# Altium schematic sheet STM_Bluetooth.SchDoc(STM_Bluetooth)
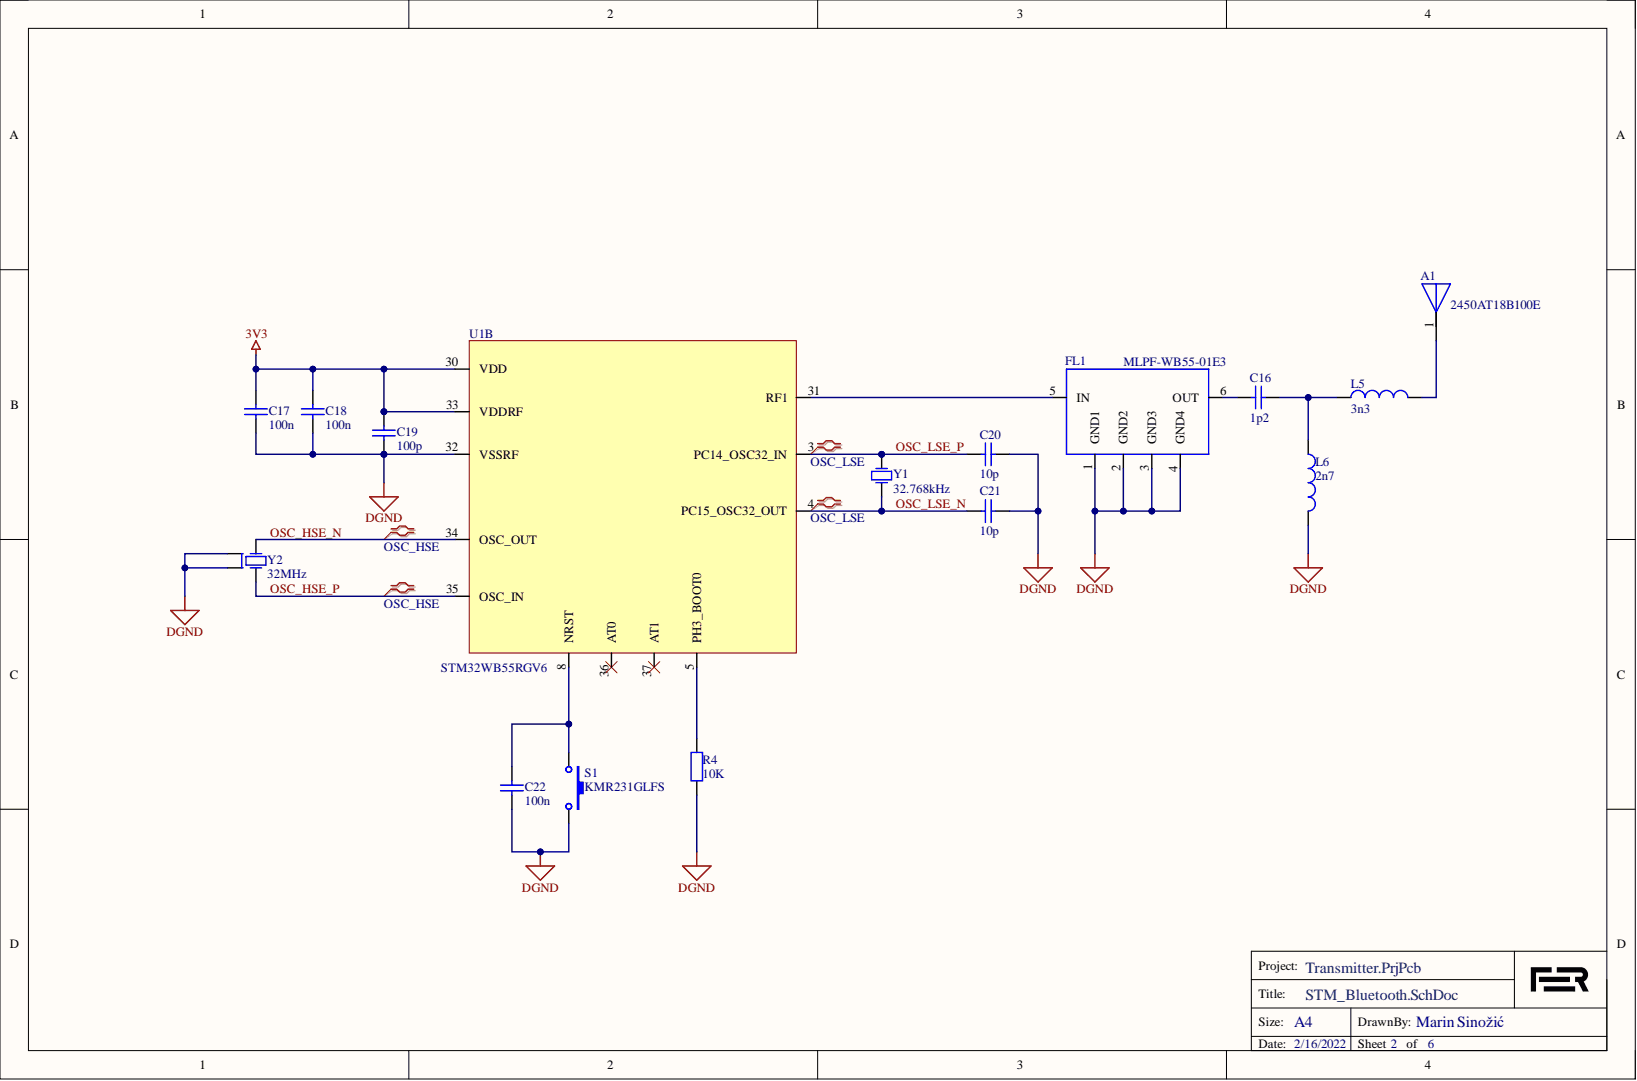
[diagram p0: STM_Bluetooth.SchDoc]

## Parts
A1  2450AT18B100E  [ANT_2450AT18B100E]
C16  1p2  [0402]
C17  100n  [0603]
C18  100n  [0603]
C19  100p  [0603]
C20  10p  [0603]
C21  10p  [0603]
C22  100n  [0603]
FL1  MLPF-WB55-01E3  [CSP_BUMPLESS]
L5  3n3  [0402]
L6  2n7  [0402]
R4  10K  [0603]
S1  KMR231GLFS  [SW-PB SMD KMR2]
U1  STM32WB55RGV6  [Model Name]  (part B)
Y1  32.768kHz  [NX2012_2P]
Y2  32MHz  [NX2016_4P]

## Nets
3V3: C17.2 C18.2 C19.2 U1.30(VDD) U1.33(VDDRF)
DGND: C17.1 C18.1 C19.1 C20.2 C21.2 C22.1 FL1.1(GND1) FL1.2(GND2) FL1.3(GND3) FL1.4(GND4) L6.2 R4.1 S1.2 U1.32(VSSRF) Y2.2 Y2.4
OSC_HSE_N: U1.34(OSC_OUT) Y2.1
OSC_HSE_P: U1.35(OSC_IN) Y2.3
OSC_LSE_N: C21.1 U1.4(PC15_OSC32_OUT) Y1.1
OSC_LSE_P: C20.1 U1.3(PC14_OSC32_IN) Y1.2
NetA1_1: A1.1 L5.2
NetC16_1: C16.1 L5.1 L6.1
NetC16_2: C16.2 FL1.6(OUT)
NetC22_2: C22.2 S1.1 U1.8(NRST)
NetFL1_5: FL1.5(IN) U1.31(RF1)
NetR4_2: R4.2 U1.5(PH3_BOOT0)

Not connected: U1 36(AT0) 37(AT1)

## Library data of the parts
A1 = BT_ANTENNA: RF ANT 2.4GHZ CHIP SOLDER SMD; Manufacturer: Johanson; Manufacturer Part Number: 712-1006-1-ND; Bandwidth: 100MHz; Frequency: 2.45GHz; Gain: -0.5dB; Impedance: 50R; Max Frequency: 2.5GHz; Max Power Dissipation: 3W; Number of Bands: 1; Return Loss: -9.5dB; Case/Package: CSMD; Category: RF Antennas; Component Kind: Standard; ComponentLink1Description: https://datasheet.ciiva.com/24025/antenna-2450at18b100-242422-24025839.pdf?src-supplier=Mouser; ComponentLink1URL: https://datasheet.ciiva.com/24025/antenna-2450at18b100-242422-24025839.pdf?src-supplier=Mouser; ComponentLink2Description: https://datasheet.ciiva.com/1124/1318299-1124918.pdf?src-supplier=Element14; ComponentLink2URL: https://datasheet.ciiva.com/1124/1318299-1124918.pdf?src-supplier=Element14; Contact Plating: Tin; Height: 0.051inch; Length: 3.2mm; Library Name: Tx_board.SchLib; Max Operating Temperature: 85°C; Min Operating Temperature: -40°C; Mount: Surface Mount; Package Quantity: 1; Packaging: Tape and Reel; Power Rating: 3W; Price: 0.56; Pricing 1: 1=0.56000, 10=0.49500, 25=0.46520, 100=0.37950, 250=0.35252, 500=0.30000, 1000=0.24000 (USD); Radiation Hardening: No; REACH SVHC: No SVHC; RoHS: Yes; Stock 1: 147317; Supplier 1: Digi-Key; Supplier Part Number 1: 712-1006-1-ND; Termination: Solder; Width: 1.6mm
C16 = Cap: CAP CER 1.2PF 50V C0G/NP0 0402; Manufacturer Part Number: 712-1268-1-ND; Component Kind: Standard; LatestRevisionDate: 17-Jul-2002; LatestRevisionNote: Re-released for DXP Platform.; Library Name: Miscellaneous Devices.IntLib; PackageDescription: Radial Cap, Thru-Hole; 2 Leads; 0.3 in Pin Spacing; PackageReference: RAD-0.3; Price: 0.18; Published: 8-Jun-2000; Signal Integrity: Cap; Simulation: CAP; Supplier 1: Digi-Key; Value: 1p2; Publisher: Altium Limited
C17 C18 C22 = Cap: CAP CER 0.1UF 50V X7R 0603; Manufacturer Part Number: 1276-CL10B104KB8WPNDCT-ND; Component Kind: Standard; LatestRevisionDate: 17-Jul-2002; LatestRevisionNote: Re-released for DXP Platform.; Library Name: Miscellaneous Devices.IntLib; PackageDescription: Radial Cap, Thru-Hole; 2 Leads; 0.3 in Pin Spacing; PackageReference: RAD-0.3; Price: 0.1; Published: 8-Jun-2000; Signal Integrity: Cap; Simulation: CAP; Supplier 1: Digi-Key; Value: 100n; Publisher: Altium Limited
C19 = Cap: CAP CER 100PF 50V X7R 0603; Manufacturer Part Number: 1276-1908-1-ND; Component Kind: Standard; LatestRevisionDate: 17-Jul-2002; LatestRevisionNote: Re-released for DXP Platform.; Library Name: Miscellaneous Devices.IntLib; PackageDescription: Radial Cap, Thru-Hole; 2 Leads; 0.3 in Pin Spacing; PackageReference: RAD-0.3; Price: 0.1; Published: 8-Jun-2000; Signal Integrity: Cap; Simulation: CAP; Supplier 1: Digi-Key; Value: 100p; Publisher: Altium Limited
C20 C21 = Cap: CAP CER 10PF 50V X7R 0603; Manufacturer Part Number: 399-C0603C100J5RAC7867CT-ND; Component Kind: Standard; LatestRevisionDate: 17-Jul-2002; LatestRevisionNote: Re-released for DXP Platform.; Library Name: Miscellaneous Devices.IntLib; PackageDescription: Radial Cap, Thru-Hole; 2 Leads; 0.3 in Pin Spacing; PackageReference: RAD-0.3; Price: 0.25; Published: 8-Jun-2000; Signal Integrity: Cap; Simulation: CAP; Supplier 1: Digi-Key; Value: 10p; Publisher: Altium Limited
FL1 = FLT_2.4GHz: RF Filter Low Pass 2400MHz to 2500MHz 1.1dB 50Ohm SMD 6Pin T/R; Manufacturer: STMicroelectronics; Component Kind: Standard; ComponentLink1Description: http://www.farnell.com/datasheets/2802556.pdf?src-supplier=Farnell; ComponentLink1URL: http://www.farnell.com/datasheets/2802556.pdf?src-supplier=Farnell; Library Name: Tx_board.SchLib; Manufacturer Part Number: MLPF-WB55-01E3; Price: 0.39; Pricing 1: 1=0.33000, 10=0.31100, 25=0.29680, 50=0.28720, 100=0.27750, 250=0.26316, 500=0.22012, 1000=0.17082 (USD); RoHS: No; Stock 1: 4457; Supplier 1: Digi-Key; Supplier Part Number 1: 497-19088-1-ND
L5 = L_0805_10uH: FIXED IND 3.3NH 300MA 190MOHM SM; Manufacturer: Murata; Manufacturer Part Number: 712-1416-1-ND; Component Kind: Standard; Library Name: Tx_board.SchLib; Price: 0.1; Pricing 1: 1=0.28000, 10=0.25300, 100=0.17390, 500=0.14864, 1000=0.11385 (USD); RoHS: No; Stock 1: 2742; Supplier 1: Digi-Key; Supplier Part Number 1: 490-18463-1-ND; Value: 3n3
L6 = L_0805_10uH: FIXED IND 2.7NH 300MA 170MOHM SM; Manufacturer: Murata; Manufacturer Part Number: 712-1415-1-ND; Component Kind: Standard; Library Name: Tx_board.SchLib; Price: 0.1; Pricing 1: 1=0.28000, 10=0.25300, 100=0.17390, 500=0.14864, 1000=0.11385 (USD); RoHS: No; Stock 1: 2742; Supplier 1: Digi-Key; Supplier Part Number 1: 490-18463-1-ND; Value: 2n7
R4 = Res2: RES SMD 10K OHM 0.5% 1/5W 0603; Manufacturer Part Number: P20397CT-ND; Component Kind: Standard; LatestRevisionDate: 17-Jul-2002; LatestRevisionNote: Re-released for DXP Platform.; Library Name: Miscellaneous Devices.IntLib; PackageDescription: Axial Device, Thru-Hole; 2 Leads; 0.4 in Pin Spacing; PackageReference: AXIAL-0.4; Price: 0.16; Published: 8-Jun-2000; Signal Integrity: Res; Simulation: RESISTOR; Supplier 1: Digi-Key; Value: 10K; Publisher: Altium Limited
S1 = SW-PB: Switch Tactile N.O. SPST Button Gull Wing 0.05A 32VDC 1VA 150000Cycles 3N SMD T/R; Manufacturer: ITT C&K; Manufacturer Part Number: 1437636; Actuator Length: 0.5mm; Actuator Type: Standard; Contact Current Rating: 50mA; Contact Resistance: 100mR; Illumination Type: Non-Illuminated,Illuminated; Insulation Resistance: 1GR; Max Voltage Rating (DC): 32V; Power Rating: 1W; Switch Function: Off-Mom; Switch Travel: 0.25mm; Case/Package: SMD/SMT; Category: Tactile Switches; Component Kind: Standard; ComponentLink1Description: https://datasheet.ciiva.com/29903/getdatasheetpartid-763492-29903765.pdf?src-supplier=Verical; ComponentLink1URL: https://datasheet.ciiva.com/29903/getdatasheetpartid-763492-29903765.pdf?src-supplier=Verical; ComponentLink2Description: https://datasheet.ciiva.com/7986/1792623-7986954.pdf?src-supplier=Element14; ComponentLink2URL: https://datasheet.ciiva.com/7986/1792623-7986954.pdf?src-supplier=Element14; ComponentLink3Description: https://datasheet.ciiva.com/16443/kmr231glfs-16443008.pdf?src-supplier=Future+Electronics; ComponentLink3URL: https://datasheet.ciiva.com/16443/kmr231glfs-16443008.pdf?src-supplier=Future+Electronics; ComponentLink4Description: https://datasheet.ciiva.com/16155/kmr231glfs-ckcomponents-16155429.pdf?src-supplier=Onlinecomponents.com; ComponentLink4URL: https://datasheet.ciiva.com/16155/kmr231glfs-ckcomponents-16155429.pdf?src-supplier=Onlinecomponents.com; ComponentLink5Description: https://datasheet.ciiva.com/2900/kmr2-9aug12-2900231.pdf?src-supplier=Digi-Key; ComponentLink5URL: https://datasheet.ciiva.com/2900/kmr2-9aug12-2900231.pdf?src-supplier=Digi-Key; ComponentLink6Description: https://datasheet.ciiva.com/2900/kmr2-9aug12-2900232.pdf?src-supplier=Digi-Key; ComponentLink6URL: https://datasheet.ciiva.com/2900/kmr2-9aug12-2900232.pdf?src-supplier=Digi-Key; ComponentLink7Description: https://datasheet.ciiva.com/2900/kmr2-9aug12-2900233.pdf?src-supplier=Digi-Key; ComponentLink7URL: https://datasheet.ciiva.com/2900/kmr2-9aug12-2900233.pdf?src-supplier=Digi-Key; ComponentLink8Description: https://datasheet.ciiva.com/26827/kmr2-9aug12-26827228.pdf?src-supplier=Verical; ComponentLink8URL: https://datasheet.ciiva.com/26827/kmr2-9aug12-26827228.pdf?src-supplier=Verical; Contact Material: Silver; Contact Plating: Silver; Current Rating: 50mA; Depth: 4.6mm; Lead Free: Lead Free; Length: 2.8mm; Library Name: Tx_board.SchLib; Max Current Rating: 50mA; Max Operating Temperature: 85°C; Mechanical Life: 150000Cycles; Min Operating Temperature: -40°C; Mount: Surface Mount; Operating Force: 3N; Orientation: Straight; Package Quantity: 1; Packaging: Tape and Reel; Price: 0,49; Pricing 1: 10=0.42000, 100=0.36300, 250=0.31300, 1000=0.24800, 2500=0.21000 (GBP); Radiation Hardening: No; REACH SVHC: No SVHC; RoHS: Yes; Stock 1: 33783; Supplier 1: Farnell; Supplier Part Number 1: 1437636; Termination: Gull Wing; Throw Configuration: SPST; Voltage Rating (DC): 32V; Width: 4.6mm
U1 = STM32WB55RGV6: MCU 32-Bit STM32WB55 ARM Cortex M4 RISC 1MB Flash 1.7V to 3.6V 68-Pin VFQFPN Tray; Component Kind: Standard; Library Name: Transmitter.SchLib
Y1 = OSC_LFXTAL073170_32.768kHz: NDK 32.76kHz Crystal Unit ±20ppm SMD 2-Pin 2.05 x 1.2 x 0.55mm; Manufacturer Part Number: 644-1219-1-ND; ESR (Equivalent Series Resistance): 80kR; Load Capacitance: 12.5pF; Case/Package: SMD/SMT; Category: Crystals; Component Kind: Standard; ComponentLink1Description: http://www.ndk.com/images/products/catalog/c_NX2012SA-STD-MUB-1_e.pdf?src-supplier=Digi-Key; ComponentLink1URL: http://www.ndk.com/images/products/catalog/c_NX2012SA-STD-MUB-1_e.pdf?src-supplier=Digi-Key; Frequency: 32.768kHz; Frequency Tolerance: 20ppm; Height: 0.024inch; Length: 0.081inch; Library Name: Tx_board.SchLib; Manufacturer: NDK; Max Operating Temperature: 85°C; Min Operating Temperature: -40°C; Mount: Surface Mount; Packaging: Tape and Reel; Price: 0.91; Pricing 1: 1=0.91000, 10=0.80500, 50=0.75200, 100=0.66460, 500=0.62964, 1000=0.52470 (USD); RoHS: Yes; Stock 1: 43560; Supplier 1: Digi-Key; Supplier Part Number 1: 644-1219-1-ND; Width: 0.047inch
Y2 = OSC_LFXTAL073170_32MHz: Crystal 32.0MHZ 10PF SMD Esr 60; Manufacturer: IQD Frequency Products; Manufacturer Part Number: 1923-1603-1-ND; Component Kind: Standard; Library Name: Tx_board.SchLib; Price: 0.66; Pricing 1: 1=0.69000, 10=0.60700, 50=0.56760, 100=0.50160, 500=0.47520 (USD); Stock 1: 1202; Supplier 1: Digi-Key; Supplier Part Number 1: 1923-1603-1-ND
